# Altium schematic sheet Sheet3.SchDoc(Sheet3)
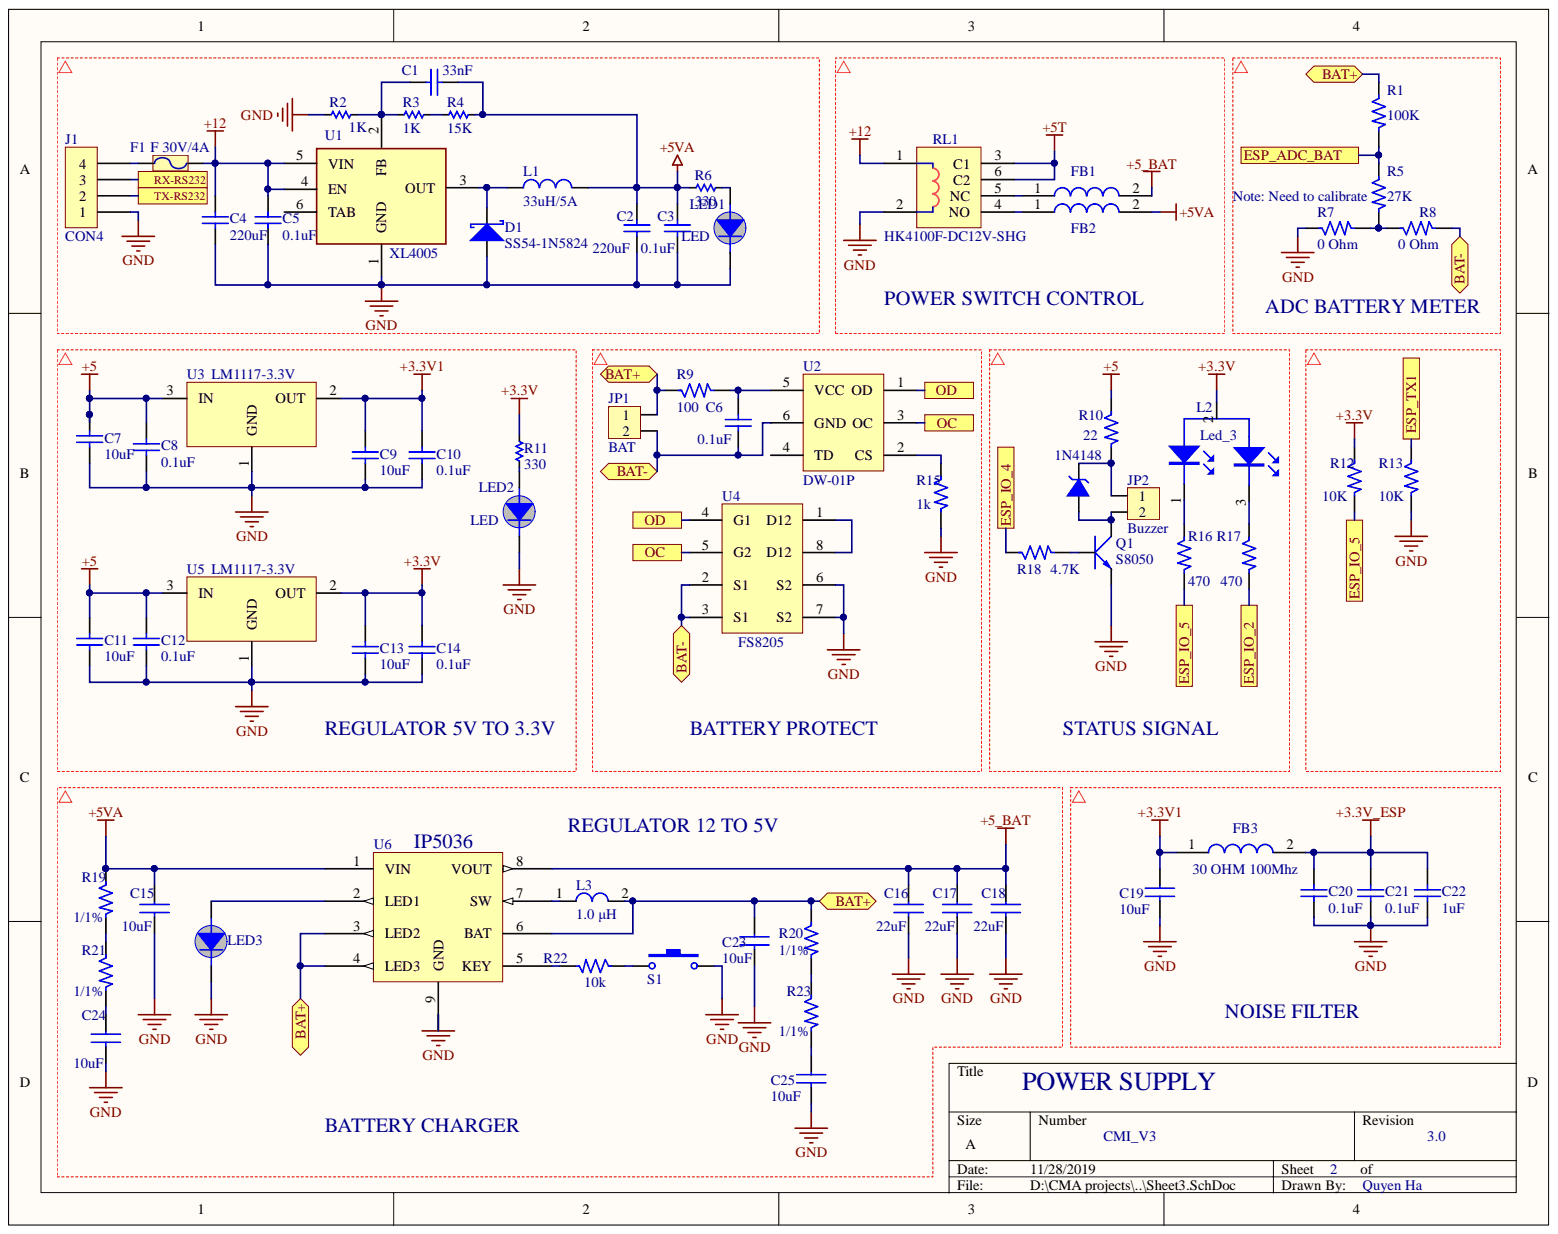
[diagram p0: Sheet3.SchDoc]

## Parts
C1  value 33nF  [0603]  (hidden comment: Capacitor Ceramic)
C2  value 220uF  [CAP-ALU-SMD-6.3x7.7x2.2]  (hidden comment: Capacitor Ceramic)
C3  value 0.1uF  [0603]  (hidden comment: Capacitor Ceramic)
C4  value 220uF  [CAP-ALU-SMD-6.3x7.7x2.2]  (hidden comment: Capacitor Ceramic)
C5  value 0.1uF  [0603]  (hidden comment: Capacitor Ceramic)
C6  value 0.1uF  [0603]  (hidden comment: Capacitor Ceramic)
C7  value 10uF  [0603]  (hidden comment: Capacitor Ceramic)
C8  value 0.1uF  [0603]  (hidden comment: Capacitor Ceramic)
C9  value 10uF  [0603]  (hidden comment: Capacitor Ceramic)
C10  value 0.1uF  [0603]  (hidden comment: Capacitor Ceramic)
C11  value 10uF  [0603]  (hidden comment: Capacitor Ceramic)
C12  value 0.1uF  [0603]  (hidden comment: Capacitor Ceramic)
C13  value 10uF  [0603]  (hidden comment: Capacitor Ceramic)
C14  value 0.1uF  [0603]  (hidden comment: Capacitor Ceramic)
C15  10uF  [CN-SMD-0603]
C16  22uF  [0805]
C17  22uF  [0805]
C18  22uF  [0805]
C19  10uF  [CN-SMD-0603]
C20  value 0.1uF  [0603]  (hidden comment: Capacitor Ceramic)
C21  value 0.1uF  [0603]  (hidden comment: Capacitor Ceramic)
C22  value 1uF  [0603]  (hidden comment: Capacitor Ceramic)
C23  10uF  [CN-SMD-0603]
C24  10uF  [CN-SMD-0603]
C25  10uF  [CN-SMD-0603]
D1  SS54-1N5824  [SMA]
D2  1N4148  [SOD-80]
F1  F 30V/4A  [Fuse - duplicate]
FB1  30 OHM 100Mhz  [0805]
FB2  30 OHM 100Mhz  [0805]
FB3  30 OHM 100Mhz  [0805]
J1  CON4  [CON4]
JP1  BAT  [CON2]
JP2  Buzzer  [CON2]
L1  33uH/5A  [Inductor high current]
L2  Led_3  [LED 10MM BI-COLOR]
L3  1.0 μH  [CD32 SMD]
LED1  LED  [LED-SMD-0805]
LED2  LED  [LED-SMD-0805]
LED3  LED  [LED-5TRED]
Q1  S8050  [SOT23B]
R1  100K  [RES-SMD-0603]
R2  1K  [RES-SMD-0603]
R3  1K  [RES-SMD-0603]
R4  15K  [RES-SMD-0603]
R5  27K  [RES-SMD-0603]
R6  330  [RES-SMD-0603]
R7  0 Ohm  [RES-SMD-0603]
R8  0 Ohm  [RES-SMD-0603]
R9  100  [RES-SMD-0603]
R10  22  [RES-SMD-0603]
R11  330  [RES-SMD-0603]
R12  10K  [RES-SMD-0603]
R13  10K  [RES-SMD-0603]
R15  1k  [RES-SMD-0603]
R16  470  [RES-SMD-0603]
R17  470  [RES-SMD-0603]
R18  4.7K  [RES-SMD-0603]
R19  1/1%  [RES-SMD-0603]
R20  1/1%  [RES-SMD-0603]
R21  1/1%  [RES-SMD-0603]
R22  10k  [RES-SMD-0603]
R23  1/1%  [RES-SMD-0603]
RL1  RL-HK4100F-DC12V-SHG  [RL_HK4100F-DC12V-SHG]  (value not drawn)
S1  SW-SMD  [SW-SMD]  (value not drawn)
U1  XL4005  [TO263-5]
U2  DW-01P  [SOT23-6]
U3  LM1117-3.3V  [SOT223-4N]
U4  FS8205  [TSSOP8]
U5  LM1117-3.3V  [SOT223-4N]
U6  IP5036  [eSOP8L]

## Ports
BAT+, BAT-, ESP_ADC_BAT, ESP_IO_2, ESP_IO_4, ESP_IO_5, ESP_TX1, OC, OD, RX-RS232, TX-RS232

## Nets
+3.3V: C13.1 C14.1 R11.1 R12.1 U5.2
+3.3V1: C9.1 C10.1 C19.1 FB3.1 U3.2
+3.3V_ESP: C20.1 C21.1 C22.1 FB3.2
+5: C7.1 C8.1 C11.1 C12.1 R10.1 U3.3 U5.3
+5_BAT: C16.1 C17.1 C18.1 FB1.2 U6.8(VOUT)
+5T: RL1.3(C1) RL1.6(C2)
+5VA: C1.2 C2.1 C3.1 C15.1 FB2.2 L1.2 R4.1 R6.1 R19.1 U6.1(VIN)
+12: C4.1 C5.1 F1.2 RL1.1 U1.4(EN) U1.5(VIN)
GND: C2.2 C3.2 C4.2 C5.2 C7.2 C8.2 C9.2 C10.2 C11.2 C12.2 C13.2 C14.2 C15.2 C16.2 C17.2 C18.2 C19.2 C20.2 C21.2 C22.2 C23.2 C24.2 C25.2 D1.1 J1.1 LED1.2 LED2.2 LED3.2 Q1.1 R2.2 R7.1 R13.2 R15.2 RL1.2 S1.2 U1.1(GND) U3.1 U4.6(S2) U4.7(S2) U5.1 U6.9(GND)
ESP_ADC_BAT: R1.2 R5.1 ; port ESP_ADC_BAT
ESP_IO_2: R17.2 ; port ESP_IO_2
ESP_IO_4: R18.1 ; port ESP_IO_4
ESP_IO_5: R12.2 R16.2 ; port ESP_IO_5
ESP_TX1: R13.1 ; port ESP_TX1
OC: U2.3(OC) U4.5(G2) ; port OC
OD: U2.1(OD) U4.4(G1) ; port OD
RX-RS232: J1.3 ; port RX-RS232
TX-RS232: J1.2 ; port TX-RS232
NetC1_1: C1.1 R2.1 R3.2 U1.2(FB)
NetC6_1: C6.1 R9.2 U2.5(VCC)
NetC6_2: C6.2 JP1.2 R8.2 U2.6(GND) U4.2(S1) U4.3(S1)
NetC23_1: C23.1 JP1.1 L3.2 R1.1 R9.1 R20.1 U6.3(LED2) U6.4(LED3) U6.6(BAT)
NetC24_1: C24.1 R21.2
NetC25_1: C25.1 R23.2
NetD1_2: D1.2 L1.1 U1.3(OUT)
NetD2_1: D2.1 JP2.2 Q1.2
NetD2_2: D2.2 JP2.1 R10.2
NetF1_1: F1.1 J1.4
NetFB1_1: FB1.1 RL1.5(NC)
NetFB2_1: FB2.1 RL1.4(NO)
NetL2_1: L2.1 R16.1
NetL2_3: L2.3 R17.1
NetL3_1: L3.1 U6.7(SW)
NetLED1_1: LED1.1 R6.2
NetLED2_1: LED2.1 R11.2
NetLED3_1: LED3.1 U6.2(LED1)
NetQ1_3: Q1.3 R18.2
NetR3_1: R3.1 R4.2
NetR5_2: R5.2 R7.2 R8.1
NetR15_1: R15.1 U2.2(CS)
NetR19_2: R19.2 R21.1
NetR20_2: R20.2 R23.1
NetR22_1: R22.1 S1.1
NetR22_2: R22.2 U6.5(KEY)
NetU4_1: U4.1(D12) U4.8(D12)

Not connected: L2 2; U1 6(TAB); U2 4(TD)

## Library data of the parts
C1-C14 C20-C22 = CapSMD: Capacitor; Simulation: CAP; Signal Integrity: Cap; Published: 8-Jun-2000; PackageReference: RAD-0.3; Library Name: Cty1.SchLib; LatestRevisionNote: Re-released for DXP Platform.; LatestRevisionDate: 17-Jul-2002; Component Kind: Standard; Publisher: Altium Limited
C15-C19 C23-C25 = CAP 0805: Right: 20; Bottom: 10; Library Name: Cty1.SchLib; Component Kind: Standard
D1 = DIODE SCHOTTKY: Schottky Diode; Library Name: Cty1.SchLib; Component Kind: Standard
D2 = DZ: Diode Zenner; Value: 3v3; Library Name: Cty1.SchLib; Component Kind: Standard
F1 = Fuse_1: Fuse; Designed by: VanDungEvn; Value: Fuse; Library Name: Cty1.SchLib; Component Kind: Standard
FB1-FB3 = Ferrit Bead 30 OHM 100Mhz: FERRITE BEAD 30 OHM 100Mhz 0805; Library Name: Cty1.SchLib; Component Kind: Standard
J1 = CON4: Connector; Library Name: Cty1.SchLib; Component Kind: Standard
JP1 JP2 = Con2: Connector 2; Value: Connector 2; Component Kind: Standard; Library Name: syhaunguyen.SchLib
L1 = L1: Inductor; Value: 150 mH; Library Name: Cty1.SchLib; Component Kind: Standard
L3 = L-SMD-1: partNo: 78477010; Manufacturer: Wurth Elektronik; Library Name: LIBRARY.IntLib; Inductance: 1.0 μH; Created Date: Nov.2015; ComponentLink2URL: http://katalog.we-online.de/pbs/datasheet/78477010.pdf; ComponentLink2Description: Datasheet; ComponentLink1URL: http://www.we-online.de; ComponentLink1Description: Manufacturer; Component Kind: Standard; Created by: Baojun Chen
LED1 LED2 = LED_SMD 0805: Library Name: Cty1.SchLib; Component Kind: Standard
LED3 = LED_phi 5: Library Name: Cty1.SchLib; Component Kind: Standard
Q1 = C1815: NPN 600mW, ECB; Value: C1815; Library Name: Cty1.SchLib; Component Kind: Standard; : C2383; : C828
R1 R5 R7-R10 R12 R13 R15-R23 = Res: Resistor 1/4W; Value: R1/4W - 1K; Library Name: Cty1.SchLib; Component Kind: Standard
R2-R4 R6 R11 = Res-SMD: Resistor; Manufacturer: Generic Components; Class: Passive; Published: 08/06/2000; Library Name: Library.SchLib; Component Kind: Standard; Publisher: Altium Hobart Technology Centre
RL1 = RL-HK4100F-DC12V-SHG: Relay 12V, 3A 250VAC 3A 30VDC, 6 pin; Library Name: Cty1.SchLib; Component Kind: Standard
S1 = SW-SMD: Switch; Published: 24-Mar-1999; PackageReference: SPST-2; Library Name: Cty1.SchLib; LatestRevisionNote: Re-released for DXP Platform.; LatestRevisionDate: 17-Jul-2002; Component Kind: Standard; Publisher: Altium Limited
U2 = DW-01: Li-ion batterry protected; Library Name: Cty1.SchLib; Component Kind: Standard
U3 U5 = LM1117: 800mA Low-Dropout Linear Regulator; Website: http://group3i.net; Library Name: Cty1.SchLib; Component Kind: Standard; Creator: Nguyen Ba Bien
U4 = FS8205A: Dual N- Channel Mosfet; Library Name: Cty1.SchLib; Component Kind: Standard
U6 = IP5306: Library Name: Cty1.SchLib; Component Kind: Standard
L2 = Led_3: Library Name: Cty1.SchLib; Component Kind: Standard
U1 = XL4005: Library Name: Cty1.SchLib; Component Kind: Standard
